# Altium schematic sheet 04 - GTP, QSPI FLASH, eMMC.SchDoc("04 - GTP, QSPI FLASH, eMMC")
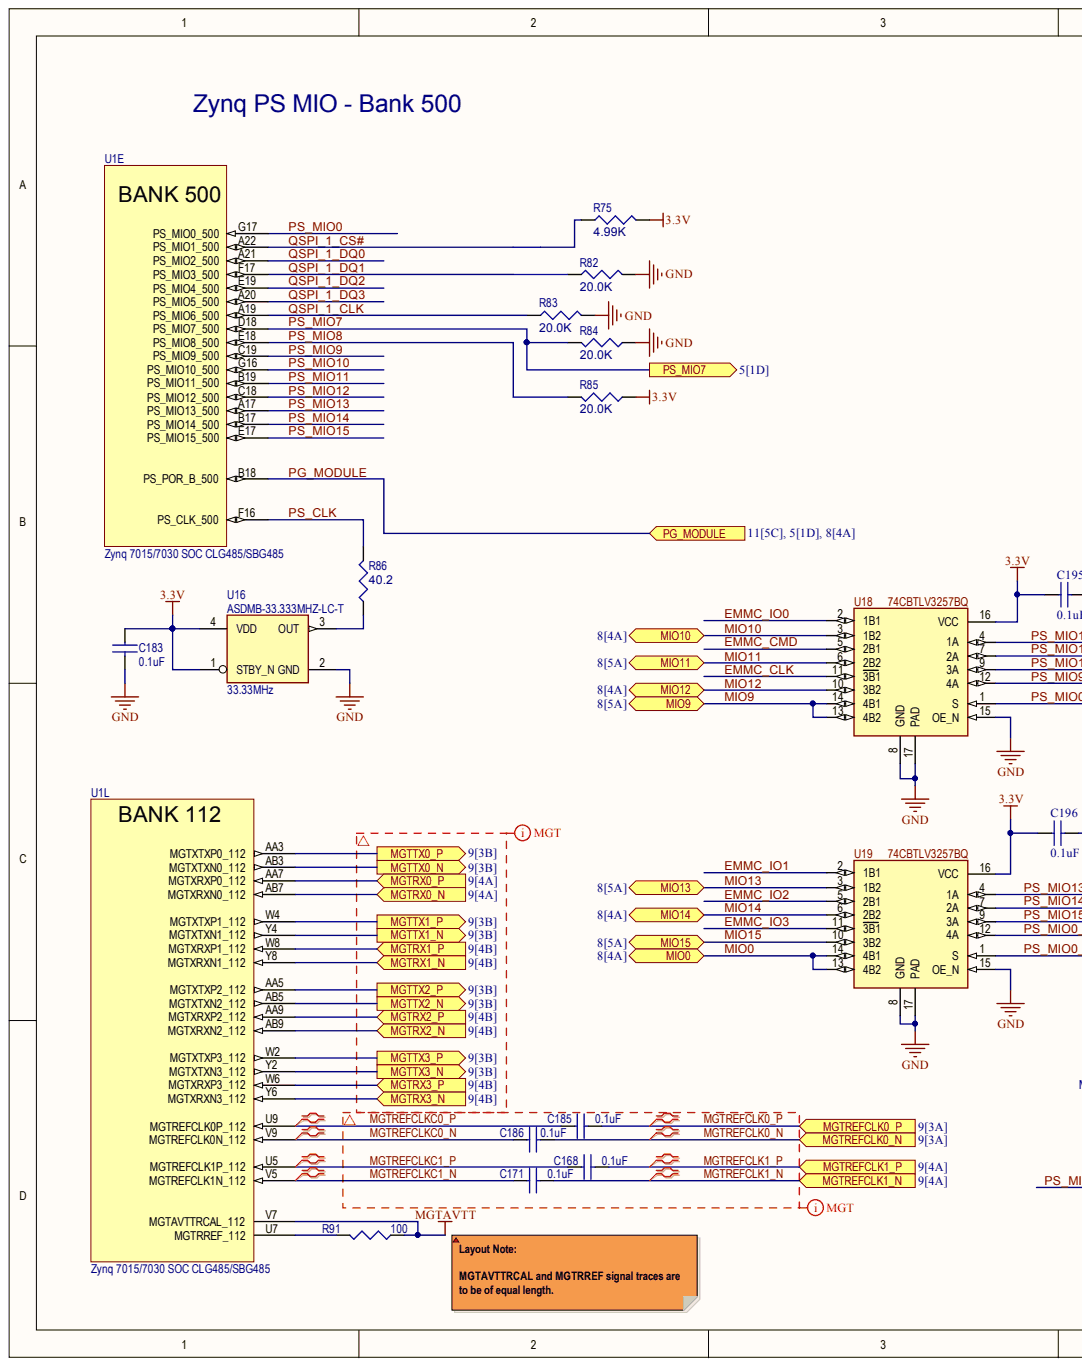
[diagram p0: 04 - GTP, QSPI FLASH, eMMC.SchDoc, left]
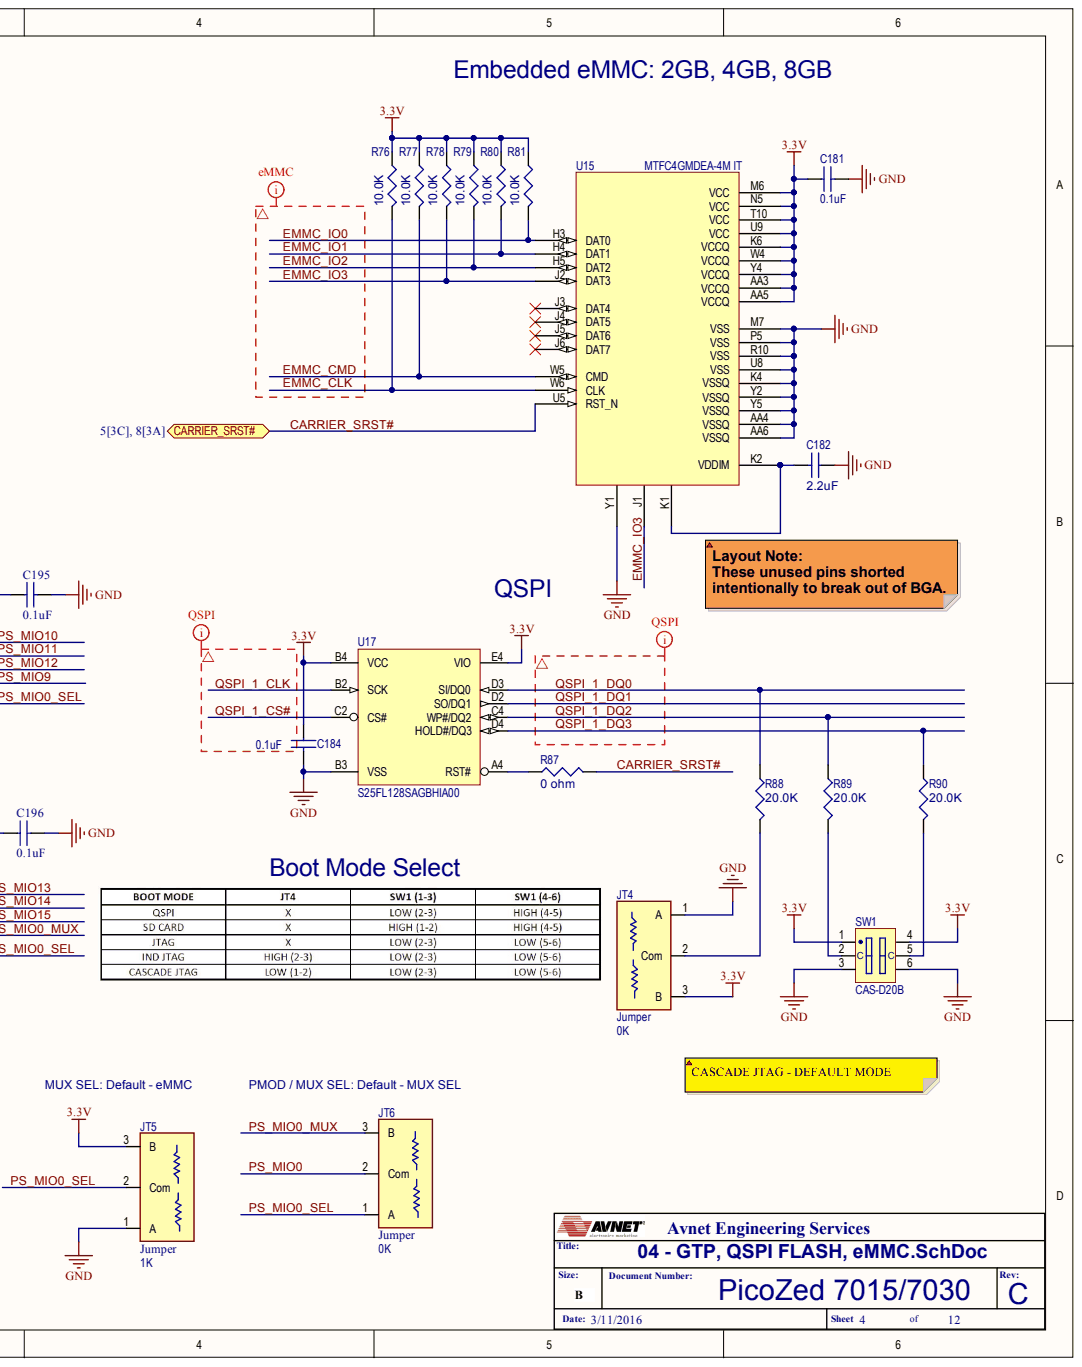
[diagram p1: 04 - GTP, QSPI FLASH, eMMC.SchDoc, right]

## Parts
C168  value 0.1uF  [C0201]  (hidden comment: PC522)
C171  value 0.1uF  [C0201]  (hidden comment: PC522)
C181  value 0.1uF  [C0201]  (hidden comment: PC522)
C182  2.2uF  [C0603]
C183  value 0.1uF  [C0201]  (hidden comment: PC522)
C184  value 0.1uF  [C0201]  (hidden comment: PC522)
C185  value 0.1uF  [C0201]  (hidden comment: PC522)
C186  value 0.1uF  [C0201]  (hidden comment: PC522)
C195  value 0.1uF  [C0201]  (hidden comment: PC522)
C196  value 0.1uF  [C0201]  (hidden comment: PC522)
JT4  value 0K  Device: Jumper  [R0402-DUAL_JMP]
JT5  value 1K  Device: Jumper  [R0402-DUAL_JMP]
JT6  value 0K  Device: Jumper  [R0402-DUAL_JMP]
R75  4.99K  [R0402]
R76  10.0K  [R0402]
R77  10.0K  [R0402]
R78  10.0K  [R0402]
R79  10.0K  [R0402]
R80  10.0K  [R0402]
R81  10.0K  [R0402]
R82  20.0K  [R0402]
R83  20.0K  [R0402]
R84  20.0K  [R0402]
R85  20.0K  [R0402]
R86  40.2  [R0402]
R87  0 ohm  [R0402]
R88  20.0K  [R0402]
R89  20.0K  [R0402]
R90  20.0K  [R0402]
R91  value 100  [R0402]  (hidden comment: 0402)
SW1  CAS-D20B  Temp: C  [CDA-D20B]
U1  Zynq 7015/7030 SOC CLG485/SBG485  [BGA81C80P22X22_1900X1900X244]  (parts E,L)
U15  MFGPN: MTFC4GMDEA-4M IT  [BGA169C50P14X28_1400X1800X70]  (hidden comment: MTFCxGxDxx-4M IT)
U16  33.33MHz  MFGPN: ASDMB-33.333MHZ-LC-T  [XTL_DSC1001-2.5X2.0]
U17  S25FL128SAGBHIA00  [BGA24_1MM_5X5]
U18  74CBTLV3257BQ  [QFN16-0.5mm]
U19  74CBTLV3257BQ  [QFN16-0.5mm]

## Ports
CARRIER_SRST#, MGTREFCLK0_N, MGTREFCLK0_P, MGTREFCLK1_N, MGTREFCLK1_P, MGTRX0_N, MGTRX0_P, MGTRX1_N, MGTRX1_P, MGTRX2_N, MGTRX2_P, MGTRX3_N, MGTRX3_P, MGTTX0_N, MGTTX0_P, MGTTX1_N, MGTTX1_P, MGTTX2_N, MGTTX2_P, MGTTX3_N, MGTTX3_P, MIO0, MIO9, MIO10, MIO11, MIO12, MIO13, MIO14, MIO15, PG_MODULE, PS_MIO7

## Nets
3.3V: C181.1 C183.1 C184.1 C195.1 C196.1 JT4.3 JT5.3 R75.2 R76.1 R77.1 R78.1 R79.1 R80.1 R81.1 R85.1 SW1.1 SW1.4 U15.AA3(VCCQ) U15.AA5(VCCQ) U15.K6(VCCQ) U15.M6(VCC) U15.N5(VCC) U15.T10(VCC) U15.U9(VCC) U15.W4(VCCQ) U15.Y4(VCCQ) U16.1(STBY_N) U16.4(VDD) U17.B4(VCC) U17.E4(VIO) U18.16(VCC) U19.16(VCC)
GND: C181.2 C182.1 C183.2 C184.2 C195.2 C196.2 JT4.1 JT5.1 R82.2 R83.2 R84.1 SW1.3 SW1.6 U15.AA4(VSSQ) U15.AA6(VSSQ) U15.K4(VSSQ) U15.M7(VSS) U15.P5(VSS) U15.R10(VSS) U15.U8(VSS) U15.Y1(NC) U15.Y2(VSSQ) U15.Y5(VSSQ) U16.2(GND) U17.B3(VSS) U18.8(GND) U18.15(OE_N) U18.17(PAD) U19.8(GND) U19.15(OE_N) U19.17(PAD)
MGTAVTT: R91.1 U1.V7(MGTAVTTRCAL_112)
CARRIER_SRST#: R87.2 U15.U5(RST_N) ; port CARRIER_SRST#
EMMC_CLK: R76.2 U15.W6(CLK) U18.11(3B1)
EMMC_CMD: R77.2 U15.W5(CMD) U18.5(2B1)
EMMC_IO0: R81.2 U15.H3(DAT0) U18.2(1B1)
EMMC_IO1: R80.2 U15.H4(DAT1) U19.2(1B1)
EMMC_IO2: R79.2 U15.H5(DAT2) U19.5(2B1)
EMMC_IO3: R78.2 U15.J1(NC) U15.J2(DAT3) U19.11(3B1)
MGTREFCLK0_N: C186.2 ; port MGTREFCLK0_N
MGTREFCLK0_P: C185.2 ; port MGTREFCLK0_P
MGTREFCLK1_N: C171.2 ; port MGTREFCLK1_N
MGTREFCLK1_P: C168.2 ; port MGTREFCLK1_P
MGTREFCLKC0_N: C186.1 U1.V9(MGTREFCLK0N_112)
MGTREFCLKC0_P: C185.1 U1.U9(MGTREFCLK0P_112)
MGTREFCLKC1_N: C171.1 U1.V5(MGTREFCLK1N_112)
MGTREFCLKC1_P: C168.1 U1.U5(MGTREFCLK1P_112)
MGTRX0_N: U1.AB7(MGTXRXN0_112) ; port MGTRX0_N
MGTRX0_P: U1.AA7(MGTXRXP0_112) ; port MGTRX0_P
MGTRX1_N: U1.Y8(MGTXRXN1_112) ; port MGTRX1_N
MGTRX1_P: U1.W8(MGTXRXP1_112) ; port MGTRX1_P
MGTRX2_N: U1.AB9(MGTXRXN2_112) ; port MGTRX2_N
MGTRX2_P: U1.AA9(MGTXRXP2_112) ; port MGTRX2_P
MGTRX3_N: U1.Y6(MGTXRXN3_112) ; port MGTRX3_N
MGTRX3_P: U1.W6(MGTXRXP3_112) ; port MGTRX3_P
MGTTX0_N: U1.AB3(MGTXTXN0_112) ; port MGTTX0_N
MGTTX0_P: U1.AA3(MGTXTXP0_112) ; port MGTTX0_P
MGTTX1_N: U1.Y4(MGTXTXN1_112) ; port MGTTX1_N
MGTTX1_P: U1.W4(MGTXTXP1_112) ; port MGTTX1_P
MGTTX2_N: U1.AB5(MGTXTXN2_112) ; port MGTTX2_N
MGTTX2_P: U1.AA5(MGTXTXP2_112) ; port MGTTX2_P
MGTTX3_N: U1.Y2(MGTXTXN3_112) ; port MGTTX3_N
MGTTX3_P: U1.W2(MGTXTXP3_112) ; port MGTTX3_P
MIO0: U19.13(4B2) U19.14(4B1) ; port MIO0
MIO9: U18.13(4B2) U18.14(4B1) ; port MIO9
MIO10: U18.3(1B2) ; port MIO10
MIO11: U18.6(2B2) ; port MIO11
MIO12: U18.10(3B2) ; port MIO12
MIO13: U19.3(1B2) ; port MIO13
MIO14: U19.6(2B2) ; port MIO14
MIO15: U19.10(3B2) ; port MIO15
PG_MODULE: U1.B18(PS_POR_B_500) ; port PG_MODULE
PS_CLK: R86.2 U1.F16(PS_CLK_500)
PS_MIO0: JT6.2 U1.G17(PS_MIO0_500)
PS_MIO0_MUX: JT6.3 U19.12(4A)
PS_MIO0_SEL: JT5.2 JT6.1 U18.1(S) U19.1(S)
PS_MIO7: R84.2 U1.D18(PS_MIO7_500) ; port PS_MIO7
PS_MIO8: R85.2 U1.E18(PS_MIO8_500)
PS_MIO9: U1.C19(PS_MIO9_500) U18.12(4A)
PS_MIO10: U1.G16(PS_MIO10_500) U18.4(1A)
PS_MIO11: U1.B19(PS_MIO11_500) U18.7(2A)
PS_MIO12: U1.C18(PS_MIO12_500) U18.9(3A)
PS_MIO13: U1.A17(PS_MIO13_500) U19.4(1A)
PS_MIO14: U1.B17(PS_MIO14_500) U19.7(2A)
PS_MIO15: U1.E17(PS_MIO15_500) U19.9(3A)
QSPI_1_CLK: R83.1 U1.A19(PS_MIO6_500) U17.B2(SCK)
QSPI_1_CS#: R75.1 U1.A22(PS_MIO1_500) U17.C2(CS#)
QSPI_1_DQ0: R88.1 U1.A21(PS_MIO2_500) U17.D3(SI/DQ0)
QSPI_1_DQ1: R82.1 U1.F17(PS_MIO3_500) U17.D2(SO/DQ1)
QSPI_1_DQ2: R89.1 U1.E19(PS_MIO4_500) U17.C4(WP#/DQ2)
QSPI_1_DQ3: R90.1 U1.A20(PS_MIO5_500) U17.D4(HOLD#/DQ3)
NetC182_2: C182.2 U15.K1(NC) U15.K2(VDDIM)
NetJT4_2: JT4.2 R88.2
NetR86_1: R86.1 U16.3(OUT)
NetR87_1: R87.1 U17.A4(RST#)
NetR89_2: R89.2 SW1.2(C)
NetR90_2: R90.2 SW1.5(C)
NetR91_2: R91.2 U1.U7(MGTRREF_112)
N00173:
N00174:
N00175:
N00176:
N00177:

Not connected: U15 J3(DAT4) J4(DAT5) J5(DAT6) J6(DAT7)

## Library data of the parts
C168 C171 C181 C183-C186 = AVPC-0000522: CAP SM 0.1uF 16V 10% X5R C0201; PartCode: PC; Database Table Name: Passive-Cap$; MFG: TDK; MFGPN: C0603X5R1C104K030BC; Temp: I; AsyTxt: PC522; Component Kind: Standard; ComponentLink1Description: Datasheet; Device: CAP; Dielectric: X5R; MFG Code: TDK; PkgType: SM; RoHS: Yes; Status: Preliminary; Tol: 10%; Volt: 16V; Library Name: Avnet_DBlib.DbLib
C182 = AVPC-0000155: CAP SM 2.2uF 6.3V 10% X5R C0603; PartCode: PC; AsyTxt: PC155; Database Table Name: Passive-Cap$; MFG: AVX; MFGPN: 06036D225KAT2A; Power: 0; Temp: I; Availability: 4K-AV; AVLNo: AVPC-0000155; Component Kind: Standard; ComponentLink1Description: Datasheet; ComponentLink2Description: MFR Website; ComponentLink2URL: www.avx.com; Cost: 0.04; Device: CAP; Dielectric: X5R; MFG Code: AVX; PkgType: SM; Received: 0; RoHS: Yes; Size: 3.4; Status: Preliminary; Tol: 10%; Value: 2.2uF; Volt: 6.3V; ComponentLink1URL: C:\AVDBLIB\DATASHEETS\AVX_CX5R.pdf; Library Name: Avnet_DBlib.DbLib
C195 C196 = AVPC-0000522: CAP SM 0.1uF 10V 10% X5R C0201; PartCode: PC; Database Table Name: Passive-Cap$; MFG: AVX; MFGPN: 02016D104KAT2A; Temp: I; AsyTxt: PC522; AVLNo: AVPC-0000522; Component Kind: Standard; ComponentLink1Description: Datasheet; Device: CAP; Dielectric: X5R; PkgType: SM; RoHS: Yes; Status: Preliminary; Tol: 10%; Volt: 10V; ComponentLink1URL: C:\AVDBLIB\DATASHEETS\AVX_CCOG.pdf; Library Name: Avnet_DBlib.DbLib
JT4 = AVPO-0000025: Jumper 3-pad 0402 Pin 1-2; PartCode: PO; Database Table Name: Passive-Other$; Device Type: 3-pad 0R0 0402; MFG Code: Vishay; MFGPN: CRCW04020000Z0ED; Note: Position 1-2; PkgType: SM; Temp: I; Component Kind: Standard; ComponentLink1Description: Datasheet; Part #: CRCW04020000Z0ED; RoHS: Yes; Status: Preliminary; ComponentLink1URL: C:\AVDBLIB\DATASHEETS\Dale_CRCW.pd; Library Name: Avnet_DBlib.DbLib
JT5 = AVPO-0000025: Jumper 3-pad 0402 RES - 1K-Ohm : Pads 1-2; PartCode: PO; Database Table Name: Passive-Other$; Device Type: 3-pad 0R0 0402; MFG: Vishay; MFGPN: CRCW04021K00FKED; Note: Position 1-2; PkgType: SM; Temp: I; Component Kind: Standard; ComponentLink1Description: Datasheet; RoHS: Yes; Status: Preliminary; ComponentLink1URL: C:\AVDBLIB\DATASHEETS\Dale_CRCW.pd; Library Name: Avnet_DBlib.DbLib
JT6 = AVPO-0000025: Jumper 3-pad 0402 RES - 0 Ohm : Pads 1-2; PartCode: PO; Database Table Name: Passive-Other$; Device Type: 3-pad 0R0 0402; MFG: Vishay; MFGPN: CRCW04020000Z0ED; Note: Position 1-2; PkgType: SM; Temp: I; Component Kind: Standard; ComponentLink1Description: Datasheet; Part #: CRCW04020000Z0ED; RoHS: Yes; Status: Preliminary; ComponentLink1URL: C:\AVDBLIB\DATASHEETS\Dale_CRCW.pd; Library Name: Avnet_DBlib.DbLib
R75 = AVPR-0000094: RES SM 4.99K 62mW 0.01 R0402; PartCode: PR; AsyTxt: PR094; Database Table Name: Passive-Res$; MFG: Vishay; MFG Code: DAL; MFGPN: CRCW04024K99FKED; Temp: I; Tol: 0.01; AVLNo: AVPR-0000094; Component Kind: Standard; ComponentLink1Description: Datasheet; Device: RES; PkgType: SM; RoHS: Yes; Status: Preliminary; Value: 4.99K; Watt: 62mW; ComponentLink1URL: C:\AVDBLIB\DATASHEETS\Dale_CRCW.pd; Library Name: Avnet_DBlib.DbLib
R76-R81 = AVPR-0000061: RES SM 10K 62mW 0.01 R0402; PartCode: PR; AsyTxt: PR061; Database Table Name: Passive-Res$; MFG: Vishay; MFG Code: DAL; MFGPN: CRCW040210K0FKED; Temp: I; Tol: 0.01; AVLNo: AVPR-0000061; Component Kind: Standard; ComponentLink1Description: Datasheet; Device: RES; PkgType: SM; RoHS: Yes; Status: Preliminary; Value: 10.0K; Watt: 62mW; ComponentLink1URL: C:\AVDBLIB\DATASHEETS\Dale_CRCW.pd; Library Name: Avnet_DBlib.DbLib
R82-R85 = AVPR-0000061: RES SM 20.0K 62mW 0.01 R0402; PartCode: PR; AsyTxt: PR061; Database Table Name: Passive-Res$; MFG: Vishay; MFG Code: DAL; MFGPN: CRCW040220K0FKED; Temp: I; Tol: 0.01; AVLNo: AVPR-0000061; Component Kind: Standard; ComponentLink1Description: Datasheet; Device: RES; PkgType: SM; RoHS: Yes; Status: Preliminary; Value: 20.0K; Watt: 62mW; ComponentLink1URL: C:\AVDBLIB\DATASHEETS\Dale_CRCW.pd; Library Name: Avnet_DBlib.DbLib
R86 = (no symbol name): RES SM 40.2 62mW 0.01 R0402; PartCode: PR; AsyTxt: PR092; MFG: Vishay; MFG Code: DAL; MFGPN: CRCW040240R2FKED; Power: 0; Temp: I; Tol: 0.01; Watt: 63mW; Availability: 2M-AV; CLASSNAME: DDR_TERMR; Component Kind: Standard; ComponentLink1Description: Datasheet; Cost: .01; Device: RES; Library Name: Z7045_MM.SchLib; PkgType: SM; Received: 0; RoHS: Yes; Size: 1.9; Status: Preliminary; Value: 40.2; Watt: 62mW; ComponentLink1URL: C:\AVDBLIB\DATASHEETS\Dale_CRCW.pd
R87 = AVPR-0000027: RES SM 0 ohm 62mW J R0402; PartCode: PR; AsyTxt: PR027; Database Table Name: Passive-Res$; MFG: Vishay; MFG Code: DAL; MFGPN: CRCW04020000Z0ED; Temp: I; AVLNo: AVPR-0000027; Component Kind: Standard; ComponentLink1Description: Datasheet; Device: RES; PkgType: SM; RoHS: Yes; Status: Preliminary; Value: 0 ohm; Watt: 62mW; ComponentLink1URL: C:\AVDBLIB\DATASHEETS\Dale_CRCW.pd; Library Name: Avnet_DBlib.DbLib
R88 R89 = AVPR-0000061: RES SM 20.0K 62mW 0.01 R0402; PartCode: PR; Database Table Name: Passive-Res$; MFG: Vishay; MFG Code: DAL; MFGPN: CRCW040220K0FKED; Temp: I; Tol: 0.01; Component Kind: Standard; Device: RES; PkgType: SM; RoHS: Yes; Status: Preliminary; Value: 20.0K; Watt: 62mW; Library Name: Avnet_DBlib.DbLib
R90 = AVPR-0000061: RES SM 20.0K 62mW 0.01 R0402; PartCode: PR; Database Table Name: Passive-Res$; MFG: Vishay; MFGPN: CRCW040220K0FKED; Temp: I; Tol: 0.01; Component Kind: Standard; Device: RES; PkgType: SM; RoHS: Yes; Status: Preliminary; Value: 20.0K; Watt: 62mW; Library Name: Avnet_DBlib.DbLib
R91 = RESC 1K0 0402: Res Thick Film 0402 100 Ohm 1% 1/16W ±100ppm/°C Molded SMD Paper T/R; MFG: Vishay; MFGPN: CRCW0402100RFKED; Temp: I; Component Kind: Standard; Signal Integrity: RES; Simulation: RES; Library Name: ZYNQ_Z7045_MITX_REVA.SCHLIB
SW1 = CAS-D20B: SLIDE SWITCH SPDT 2POS 6V; MFG: Copal Electronics; Component Kind: Standard; Library Name: new.SchLib; MFGPN: CAS-D20B; Part #: CAS-D20B
U1 = Zynq 7015/7030 SOC SBG485: MFG: Xilinx; MFGPN: XC7Z030-1SBG485-C; Temp: C; CLASSNAME: BGA; Component Kind: Standard; Description: Zynq 7015/7030 SOC SBG485; Library Name: PicoZed+_Rev_A.SCHLIB
U15 = eMMC: eMMC Memory: 4GB/8GB/16GB/32GB/64GB, 153-ball/169-ball FBGA, 3.3V; MFG: Micron; MFGPN_ALT1: MTFC8GLDEA-4M IT; Temp: I; Component Kind: Standard; Library Name: PicoZed+_Rev_A.SCHLIB; MFGPN_ALT2: MTFC16GJDEC-4M IT; MFGPN_ALT3: MTFC32GJDED-4M IT; MFGPN_ALT4: MTFC64GJDDN-4M IT
U16 = DSC1001DI1-033.3300: OSC, SM, 1.8V 33.3300MHz, 50ppm,  2.5x2.0mm; AVLNo: AVIT-0000119; MFG: Abracon; Temp: C; Component Kind: Standard; Library Name: Zynq_Z010_ZedStick.SchLib
U17 = S25FL128SAGBHI200: Quad SPI Flash, 128Mbit, 3.3V; AVLNo: AVIM-0000100; MFG: Spansion; Temp: I; CLassName: BGA; Component Kind: Standard; Library Name: Zynq_Z010_ZedStick.SchLib; MFGPN: S25FL128SAGBHIA00
U18 U19 = (no symbol name): Quad 1-of-2 Multiplexer/Demultiplexer; MFG: NXP; Temp: C; Component Kind: Standard; Library Name: new.SchLib; MFG Code: NXP; MFGPN: 74CBTLV3257BQ; Status: Preliminary
